# Altium schematic sheet 3.WIZ750SR_EVB_ETC.SchDoc
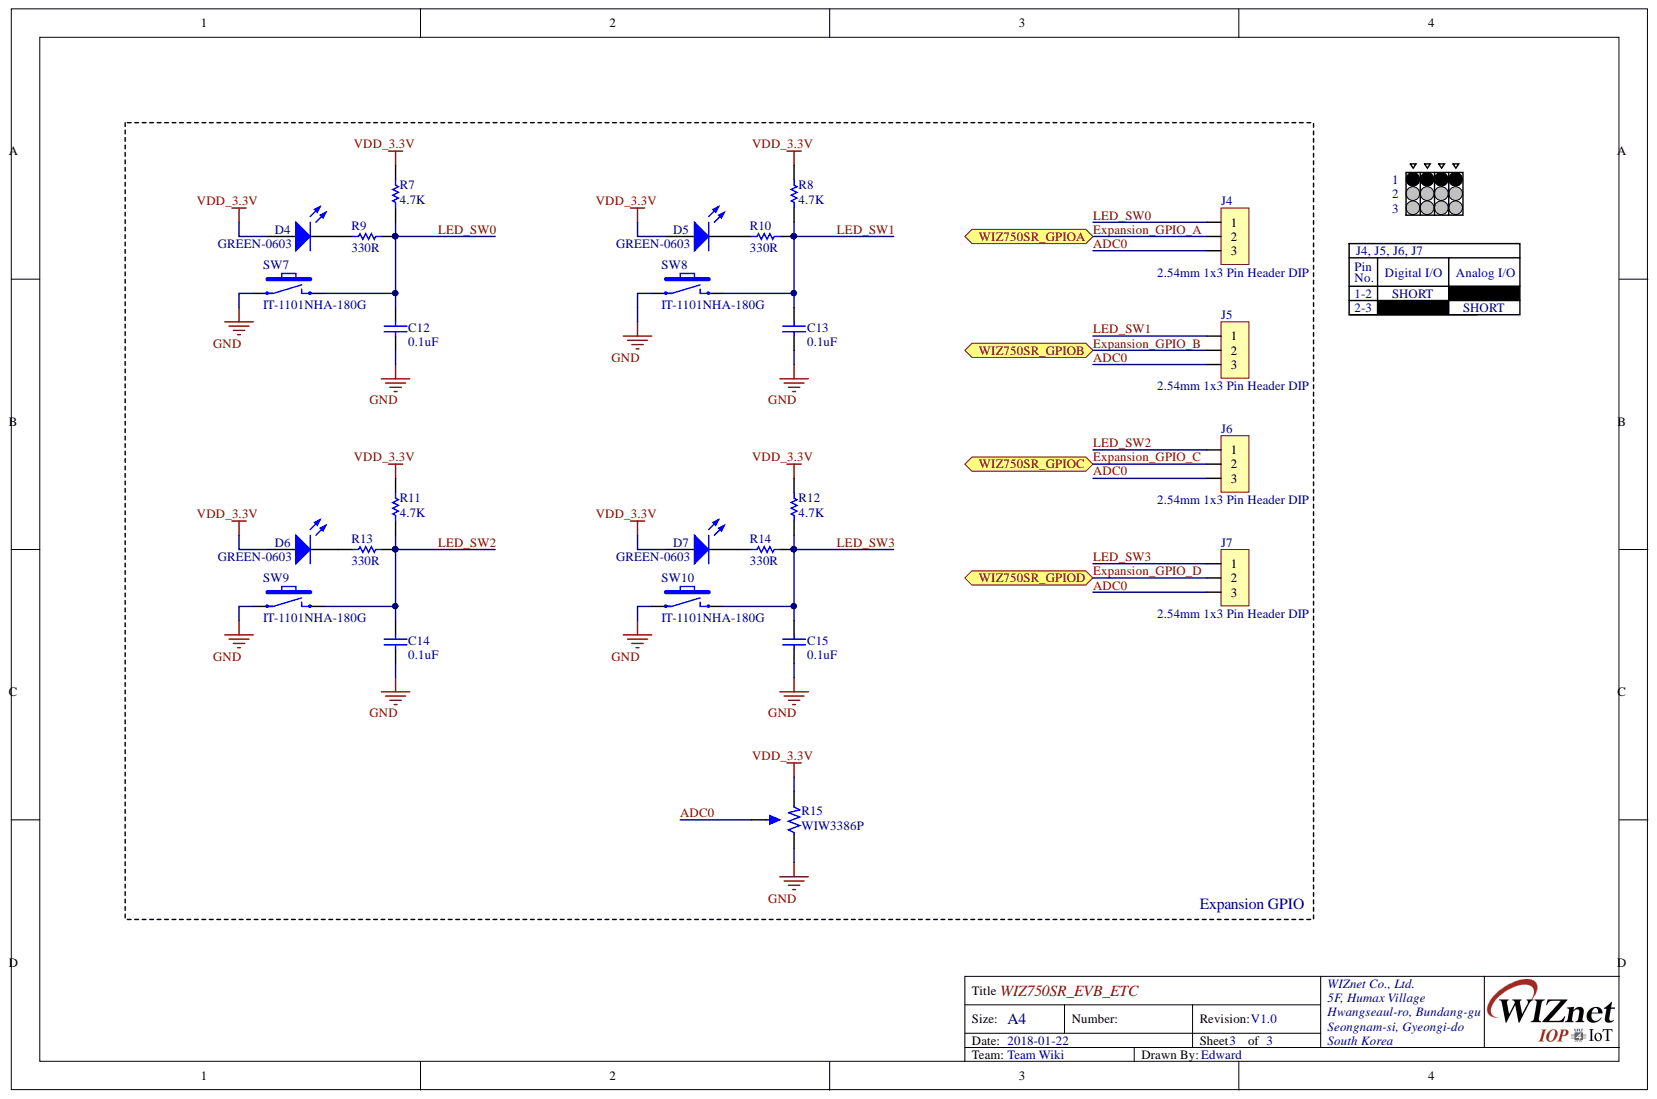
[diagram p0: 3.WIZ750SR_EVB_ETC.SchDoc]

## Parts
C12  0.1uF  [CAP-0603]
C13  0.1uF  [CAP-0603]
C14  0.1uF  [CAP-0603]
C15  0.1uF  [CAP-0603]
D4  GREEN-0603  [LED-0603-GREEN]
D5  GREEN-0603  [LED-0603-GREEN]
D6  GREEN-0603  [LED-0603-GREEN]
D7  GREEN-0603  [LED-0603-GREEN]
J4  2.54mm 1x3 Pin Header DIP  [IDC-1X3]
J5  2.54mm 1x3 Pin Header DIP  [IDC-1X3]
J6  2.54mm 1x3 Pin Header DIP  [IDC-1X3]
J7  2.54mm 1x3 Pin Header DIP  [IDC-1X3]
R7  4.7K  [RES-0603]
R8  4.7K  [RES-0603]
R9  330R  [RES-0603]
R10  330R  [RES-0603]
R11  4.7K  [RES-0603]
R12  4.7K  [RES-0603]
R13  330R  [RES-0603]
R14  330R  [RES-0603]
R15  WIW3386P  [WIW3386P]
SW7  IT-1101NHA-180G  [IT-1101NHA]
SW8  IT-1101NHA-180G  [IT-1101NHA]
SW9  IT-1101NHA-180G  [IT-1101NHA]
SW10  IT-1101NHA-180G  [IT-1101NHA]

## Ports
WIZ750SR_GPIOA, WIZ750SR_GPIOB, WIZ750SR_GPIOC, WIZ750SR_GPIOD

## Nets
GND: C12.1 C13.1 C14.1 C15.1 R15.3 SW7.1 SW8.1 SW9.1 SW10.1
VDD_3.3V: D4.1 D5.1 D6.1 D7.1 R7.2 R8.2 R11.2 R12.2 R15.1
ADC0: J4.3 J5.3 J6.3 J7.3 R15.2
EXPANSION_GPIO_A: J4.2 ; port WIZ750SR_GPIOA
EXPANSION_GPIO_B: J5.2 ; port WIZ750SR_GPIOB
EXPANSION_GPIO_C: J6.2 ; port WIZ750SR_GPIOC
EXPANSION_GPIO_D: J7.2 ; port WIZ750SR_GPIOD
LED_SW0: C12.2 J4.1 R7.1 R9.2 SW7.2
LED_SW1: C13.2 J5.1 R8.1 R10.2 SW8.2
LED_SW2: C14.2 J6.1 R11.1 R13.2 SW9.2
LED_SW3: C15.2 J7.1 R12.1 R14.2 SW10.2
NetD4_2: D4.2 R9.1
NetD5_2: D5.2 R10.1
NetD6_2: D6.2 R13.1
NetD7_2: D7.2 R14.1

## Library data of the parts
C12-C15 = CAP-0603: Ceramic CAP 0603(1608); Component Kind: Standard; Library Name: Scott_lib.IntLib
D4-D7 = LED-0603: Component Kind: Standard; Description: LED-0603; Library Name: Scott_lib.IntLib
R7-R14 = RES-0603: Component Kind: Standard; Description: RES-0603; Library Name: Scott_lib.IntLib
R15 = WIW3386P: Potentiometer; Component Kind: Standard; Library Name: Edward.IntLib
SW7-SW10 = IT-1101NHA-180G: TACT Switch (SMD); Component Kind: Standard; Library Name: Scott_lib.IntLib
J4-J7 = 2.54mm 1x3 Pin Header DIP: Component Kind: Standard; Description: 2.54mm 1x3 Pin Header DIP; Library Name: Scott_lib.IntLib
